# Altium schematic sheet arduino-pt-bone.SchDoc
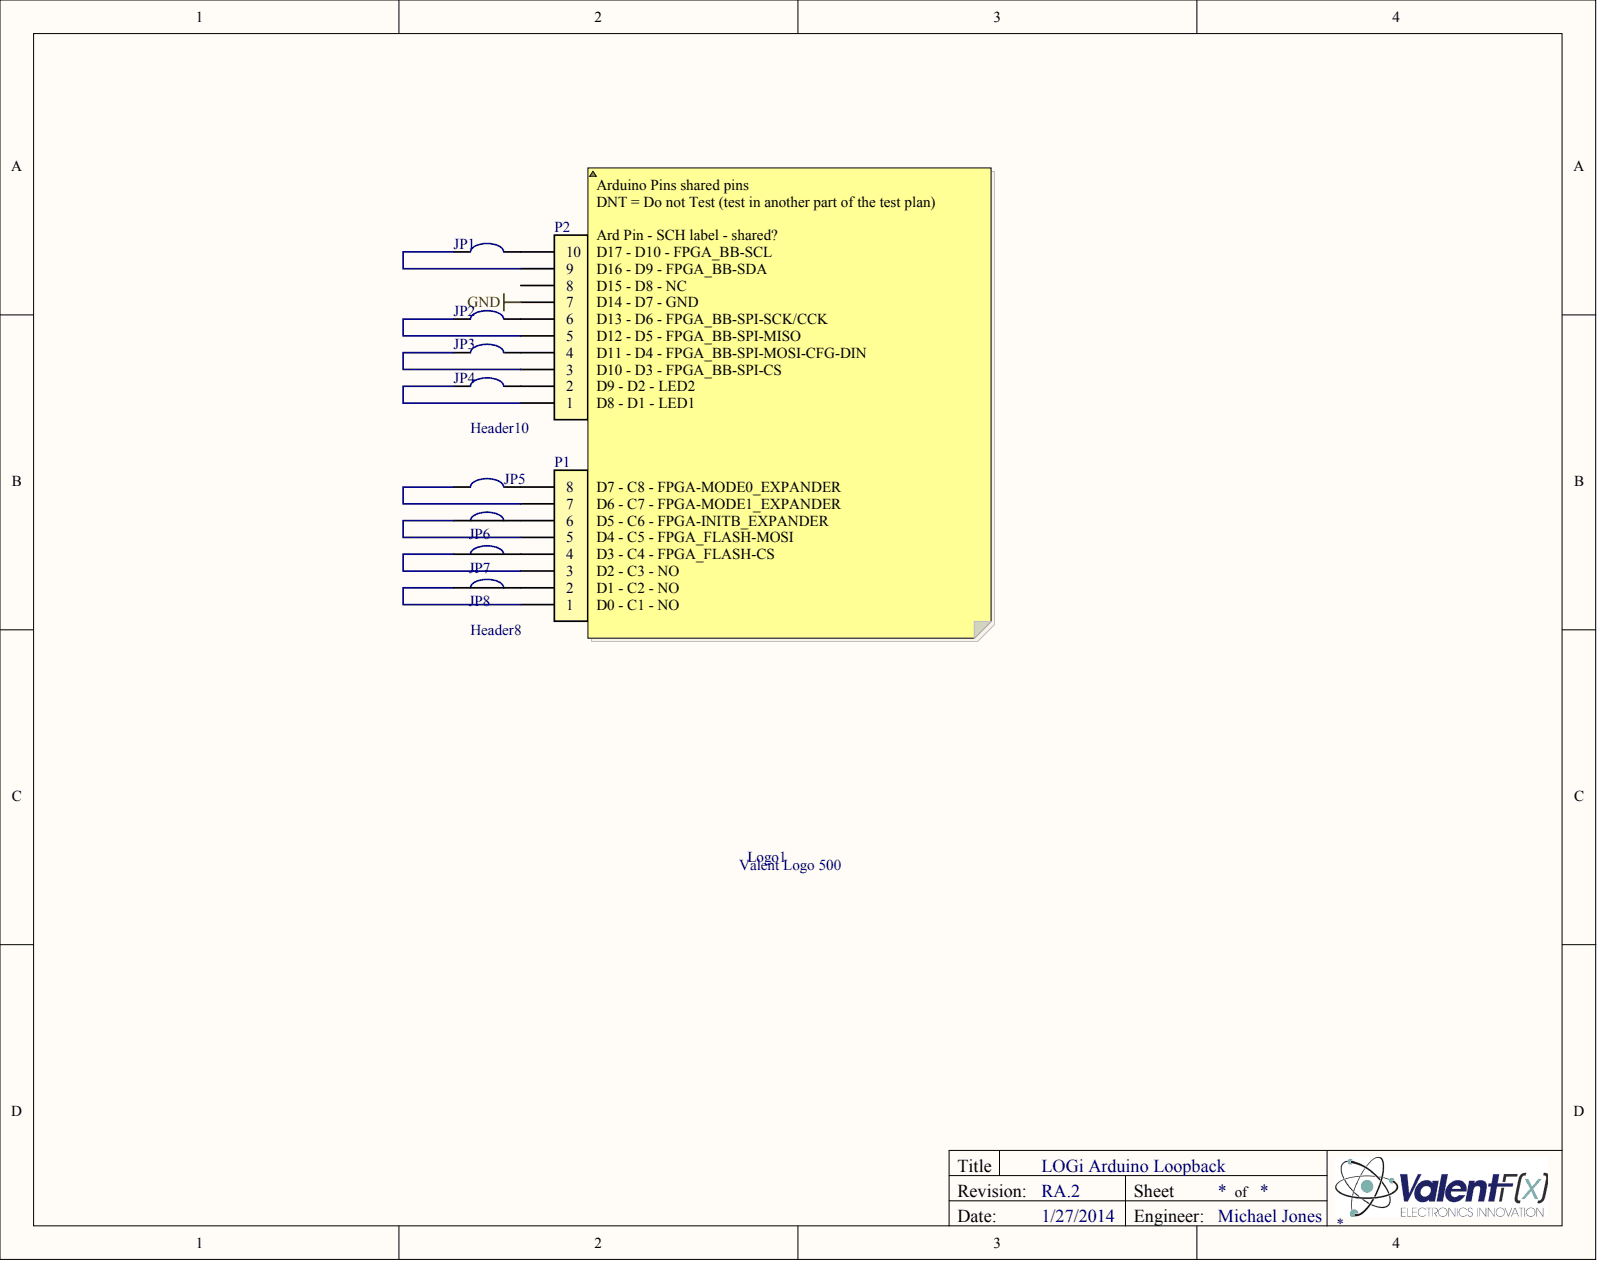
[diagram p0: arduino-pt-bone.SchDoc]

## Parts
JP1  Solder Jumper-NO  (value not drawn)
JP2  Solder Jumper-NO  (value not drawn)
JP3  Solder Jumper-NO  (value not drawn)
JP4  Solder Jumper-NO  (value not drawn)
JP5  Solder Jumper-NO  (value not drawn)
JP6  Solder Jumper-NC  (value not drawn)
JP7  Solder Jumper-NC  (value not drawn)
JP8  Solder Jumper-NC  (value not drawn)
Logo1  Valent Logo 500
P1  Header8
P2  Header10

## Nets
GND: P2.7
NetJP1_1: JP1.1 P2.9
NetJP1_2: JP1.2 P2.10
NetJP2_1: JP2.1 P2.5
NetJP2_2: JP2.2 P2.6
NetJP3_1: JP3.1 P2.3
NetJP3_2: JP3.2 P2.4
NetJP4_1: JP4.1 P2.1
NetJP4_2: JP4.2 P2.2
NetJP5_1: JP5.1 P1.7
NetJP5_2: JP5.2 P1.8
NetJP6_1: JP6.1 P1.5
NetJP6_2: JP6.2 P1.6
NetJP7_1: JP7.1 P1.3
NetJP7_2: JP7.2 P1.4
NetJP8_1: JP8.1 P1.1
NetJP8_2: JP8.2 P1.2

Not connected: P2 8

## Library data of the parts
JP1-JP8 Logo1 P1 P2 = (no symbol name)
  JP1-JP8: Manufacturer: n/a; Manufacturer Part Number: n/a; Source: n/a; Source Part Number: n/a; ROHS Certificate: n/a
